# Altium schematic sheet Bluetooth.SchDoc
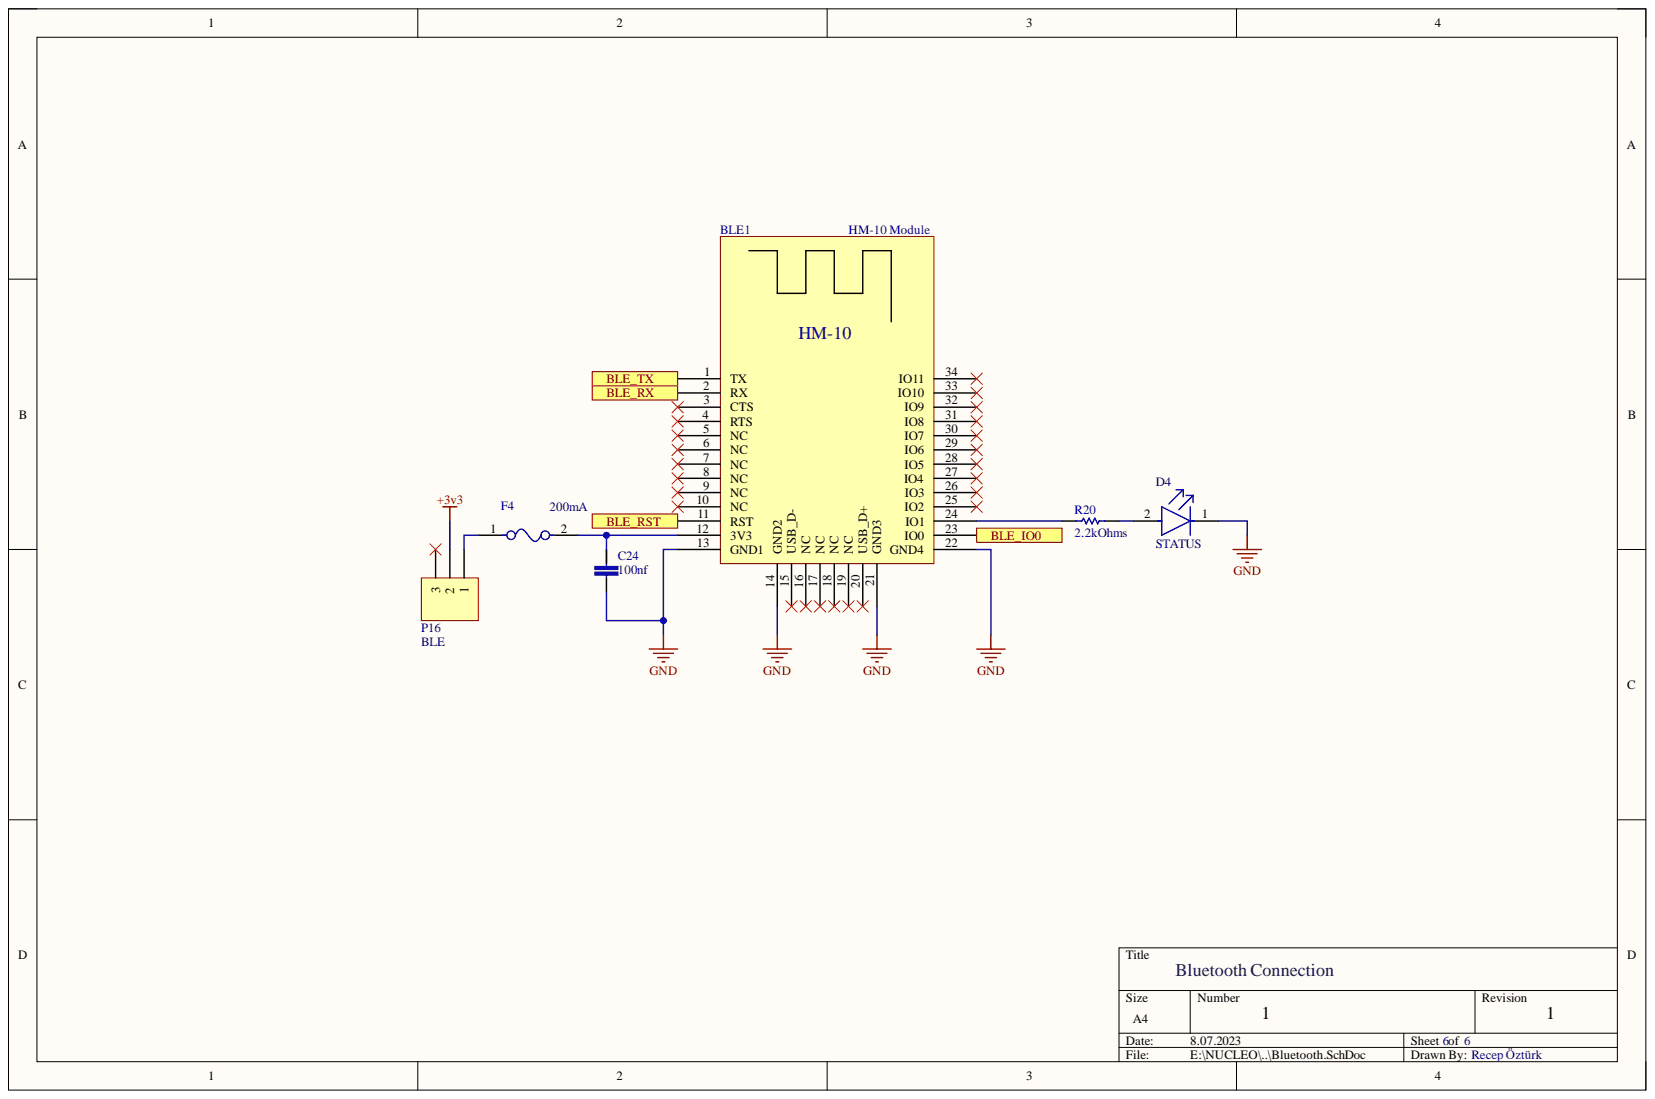
[diagram p0: Bluetooth.SchDoc]

## Parts
BLE1  HM-10 Module  [HM-10 Footprint]
C24  100nf  [CAPC1005X55N]
D4  STATUS  [FP-APT1608QBC-D-MFG]
F4  200mA  [FP-MF-NSMF012-2-MFG]
P16  BLE  [HDR1X3]  (jumper)
R20  value 2.2kOhms  [RESC1608X50N]  (hidden comment: Res1)

## Ports
BLE_IO0, BLE_RST, BLE_RX, BLE_TX

## Nets
+3v3: P16.2
GND: BLE1.13(GND1) BLE1.14(GND2) BLE1.21(GND3) BLE1.22(GND4) C24.2 D4.1
BLE_IO0: BLE1.23(IO0) ; port BLE_IO0
BLE_RST: BLE1.11(RST) ; port BLE_RST
BLE_RX: BLE1.2(RX) ; port BLE_RX
BLE_TX: BLE1.1(TX) ; port BLE_TX
NetBLE1_12: BLE1.12(3V3) C24.1 F4.2
NetBLE1_24: BLE1.24(IO1) R20.1
NetD4_2: D4.2 R20.2
NetF4_1: F4.1 P16.1

Not connected: BLE1 3(CTS) 4(RTS) 5(NC) 6(NC) 7(NC) 8(NC) 9(NC) 10(NC) 15(USB_D-) 16(NC) 17(NC) 18(NC) 19(NC) 20(USB_D+) 25(IO2) 26(IO3) 27(IO4) 28(IO5) 29(IO6) 30(IO7) 31(IO8) 32(IO9) 33(IO10) 34(IO11); P16 3

## Library data of the parts
BLE1 = HM-10 Module: HM-10 Bluetooth Module  https://www.robolinkmarket.com/hm10-bluetooth-40-cc2541; Component Kind: Standard; Library Name: Schlib1.SchLib
C24 = CL05B104KO5NFNC: 100nF 16v 0402  LCSC = C1525; MF: {'manufacturer': u'Samsung'}; Availability: Unavailable; Component Kind: Standard; Library Name: SnapEDA_Library.IntLib; MP: CL05B104KO5NFNC; Package: None; Price: None
D4 = CMP-2000-05788-2: Light Emitting Diodes (LED) Blue 465~475nm 11.5~28.5mcd@5mA Top View 0603 RoHS C72041; Dominant Wavelength: 465nm; Forward Current: 20mA; Forward Voltage: 3.3V; Lens Color: Colorless; Lens Style: Flat; Lens Transparency: Clear; Luminous Intensity: 100mcd; Number of LEDs: 1; Viewing Angle: 120°; Case Code (Metric): 1608; Case Code (Imperial): 0603; Case/Package: 0603; Color: Blue; Component Kind: Standard; Height: 0.75mm; Lead Free: Lead Free; Length: 1.6mm; Library Name: Altium Content Vault; Max Operating Temperature: 85°C; Min Operating Temperature: -40°C; Mount: Surface Mount; Number of Pins: 2; Package Quantity: 2000; Packaging: Tape and Reel; Test Current: 20mA; Width: 0.8mm; Illumination Color: Blue
F4 = CMP-07400-000025-1: PTC RESET FUSE 30V 200mA 1206  Direnc.net; Manufacturer: Bourns Inc.; Manufacturer Part Number: MF-NSMF012-2; Automotive Grade: Grade 3; Current Rating: 10A; Hold Current: 0.12A; Resistance: 1.35-8.5Ω; Trip Current: 0.29A; Trip Time: 0.2s; Value: 1.35-8.5Ω; Ambient Temperature Range High: +85°C; Ambient Temperature Range Low: -40°C; Automotive: Yes; Category: Fuse; Component Kind: Standard; ComponentLink2Description: Datasheet; ComponentLink2URL: https://www.bourns.com/docs/Product-Datasheets/mfnsmf.pdf; Device Class L1: Circuit Protection; Device Class L2: PTC Resettable Fuses; Device Class L3: unset; Digikey Description: PTC RESET FUSE 30V 120MA 1206; Height: 1.1mm; Lead Free: Yes; Library Name: Altium Content Vault; Mouser Description: Resettable Fuses - PPTC 0.12A 30V 1.35ohm; Octopart: https://octopart.com/mf-nsmf012-2-bourns-24569; Package: MF-NSMF012-2; RoHS: TRUE; Temperature: -40°C to +85°C; Temperature Range High: +85°C; Temperature Range Low: -40°C; Voltage Rating: 30V
P16 = Header 3: Header, 3-Pin; LatestRevisionDate: 17-Jul-2002; LatestRevisionNote: Re-released for DXP Platform.; Library Name: Miscellaneous Connectors.IntLib; Signal Integrity: Connector; Publisher: Altium Limited
R20 = Res1: Chip Resistor - Surface Mount 2.2KOhms ±1% 1/10W 0603 RoHS C4190; Component Kind: Standard; LatestRevisionDate: 17-Jul-2002; LatestRevisionNote: Re-released for DXP Platform.; Library Name: Miscellaneous Devices.IntLib; PackageDescription: Axial Device, Thru-Hole; 2 Leads; 0.3 in Pin Spacing; PackageReference: AXIAL-0.3; Published: 8-Jun-2000; Signal Integrity: Res; Simulation: RESISTOR; Publisher: Altium Limited
